# Altium schematic sheet 08_6V-DC_A.SchDoc(08_6V-DC_A)
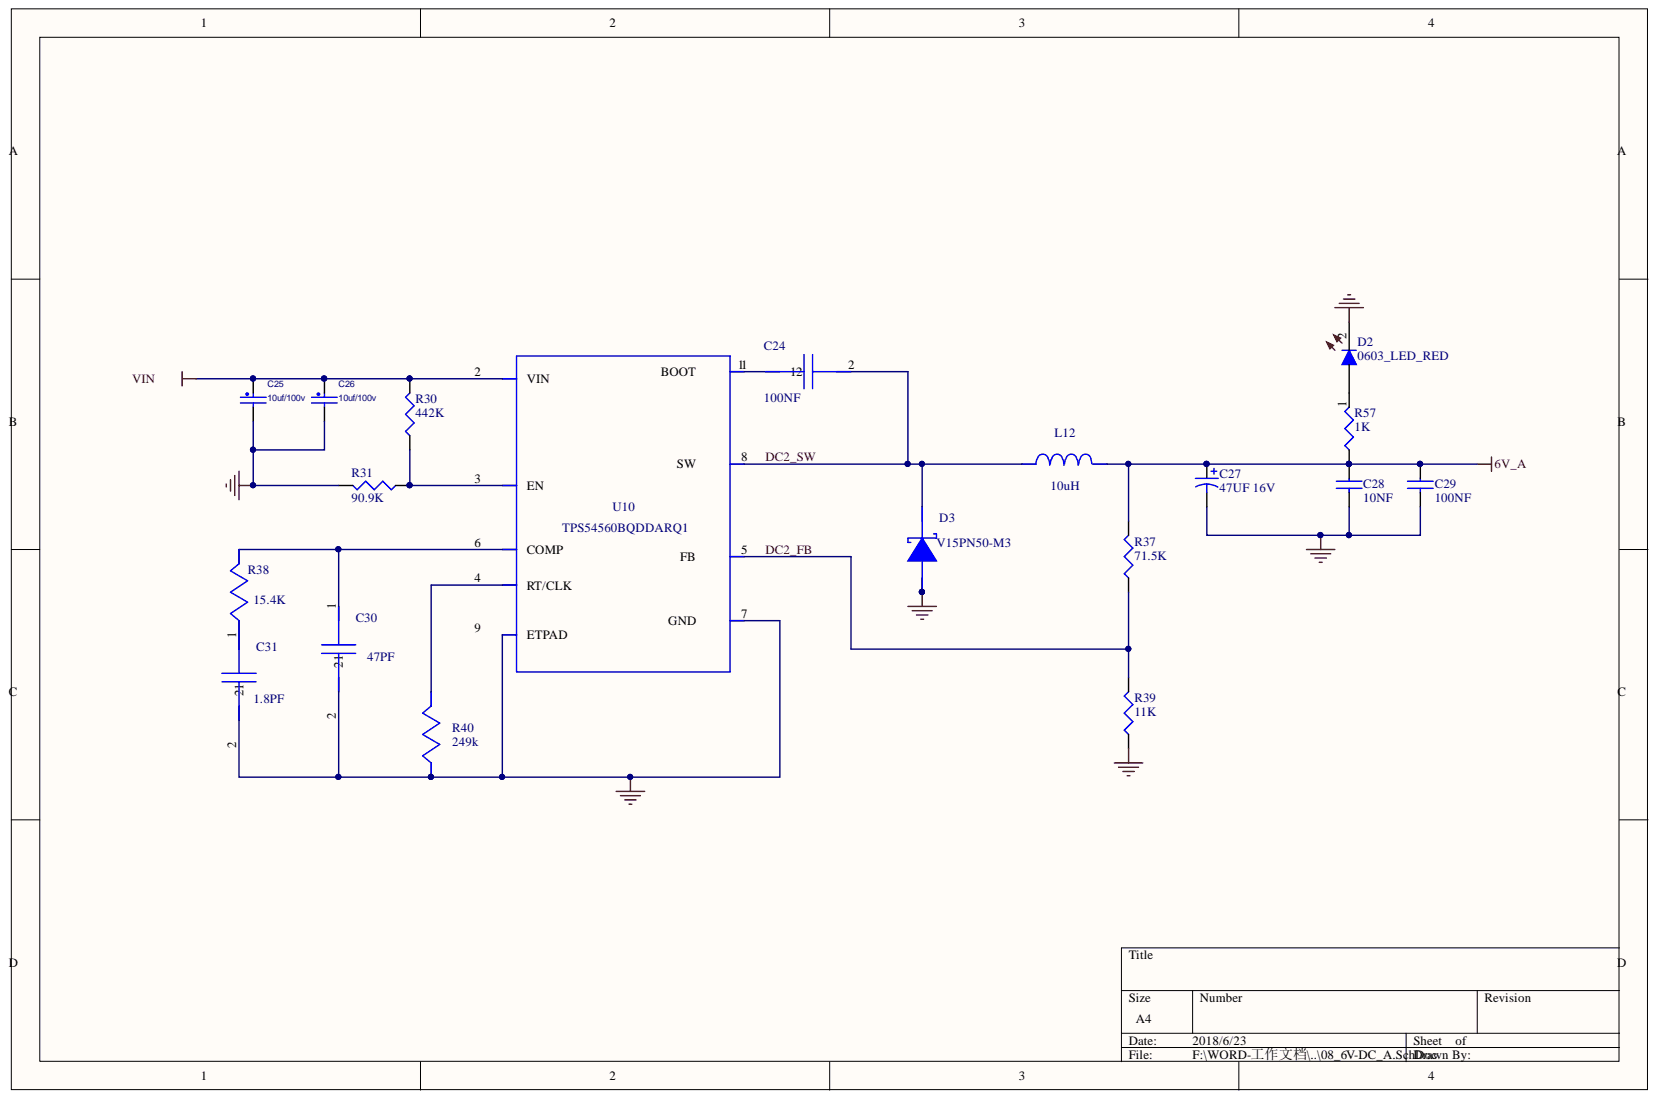
[diagram p0: 08_6V-DC_A.SchDoc]

## Parts
C24  100NF  [0402c]
C25  10uf/100v  [C2220]
C26  10uf/100v  [C2220]
C27  47UF 16V  [7343CAP]
C28  10NF  [0402C]
C29  100NF  [0402C]
C30  47PF  [0402c]
C31  1.8PF  [0402c]
D2  0603_LED_RED  [0603-LED-RED]
D3  V15PN50-M3  [TO-277]
L12  value 10uH  [10X10L]  (hidden comment: PCMB104T-100MS)
R30  442K  [R0402]
R31  90.9K  [R0402]
R37  71.5K  [R0402]
R38  15.4K  [0402R]
R39  11K  [R0402]
R40  249k  [0402R]
R57  1K  [R0402]
U10  TPS54560BQDDAR  [SO_P_8]

## Nets
6V_A: C27.A C28.1 C29.1 L12.2 R37.2 R57.1
GND: C25.2 C26.2 C27.C C28.2 C29.2 C30.2 C31.2 D2.2 D3.A R31.2 R39.1 R40.2 U10.7 U10.9(ETPAD)
VIN: C25.1 C26.1 R30.2 U10.2(VIN)
DC2_FB: R37.1 R39.2 U10.5
DC2_SW: C24.2 D3.K L12.1 U10.8
NetC24_1: C24.1 U10.1
NetC30_1: C30.1 R38.1 U10.6(COMP)
NetC31_1: C31.1 R38.2
NetD2_1: D2.1 R57.2
NetR30_1: R30.1 R31.1 U10.3(EN)
NetR40_1: R40.1 U10.4(RT/CLK)

## Library data of the parts
C24 C30 = GRM1555C1H9R1CA01D: GRM1555C1H9R1CA0; Manufacturer Name: MuRata; Component Kind: Standard; Library Name: TI WEBENCH; Manufacturer Part Number: GRM1555C1H9R1CA01D; PackageReference: GRM1555C1H9R1CA01D
  C24: Value: 100NF
C25 C26 = CAP_NP: Component Kind: Standard
C27 = Cap Pol1: T520V476M016ATE; Component Kind: Standard; LatestRevisionDate: 17-Jul-2002; LatestRevisionNote: Re-released for DXP Platform.; Library Name: Miscellaneous Devices.IntLib; PackageDescription: Polarized Capacitor (Radial); 2 Leads; PackageReference: RB7.6-15; Published: 8-Jun-2000; Signal Integrity: Cap Pol; Simulation: CAP; Publisher: Altium Limited
C28 C29 = C1005X5R1H104K: Component Kind: Standard; Library Name: Integrated_Library_RF_FEB_2012.IntLib
C31 = GRM3195C1H113JA01D: GRM3195C1H113JA0; Manufacturer Name: MuRata; Component Kind: Standard; Library Name: TI WEBENCH; Manufacturer Part Number: GRM3195C1H113JA01D; PackageReference: GRM3195C1H113JA01D
D3 = STPS20M100SG-TR: Manufacturer Name: STMicroelectronics; Component Kind: Standard; Library Name: TI WEBENCH; Manufacturer Part Number: STPS20M100SG-TR; PackageReference: STPS20M100SG-TR
L12 = SRP1270-100M: Manufacturer Name: Bourns; Component Kind: Standard; Description: SRP1270-100M; Library Name: TI WEBENCH; Manufacturer Part Number: SRP1270-100M; PackageReference: SRP1270-100M
R30 R31 R37 R39 R57 = RC0402FR-0749R9L: Component Kind: Standard; Library Name: Integrated_Library_RF_FEB_2012.IntLib
R38 = CRCW0402274KFKED: Component Kind: Standard; Library Name: TI WEBENCH
R40 = CRCW0402274KFKED: Manufacturer Name: Vishay-Dale; Component Kind: Standard; Description: CRCW0402274KFKED; Library Name: TI WEBENCH; Manufacturer Part Number: CRCW0402274KFKED; PackageReference: CRCW0402274KFKED
U10 = TPS54560BQDDARQ1: Manufacturer Name: Texas Instruments; Component Kind: Standard; Description: TPS54560BQDDARQ1; Library Name: TI WEBENCH; Manufacturer Part Number: TPS54560BQDDARQ1; PackageReference: TPS54560BQDDARQ1; Value: Value
D2 = 0603_LED_RED: Component Kind: Standard; Description: 0603_LED_RED; Library Name: CUAV.SchLib
